# Altium schematic sheet Brightside_Telemetry_power_rev2.SchDoc
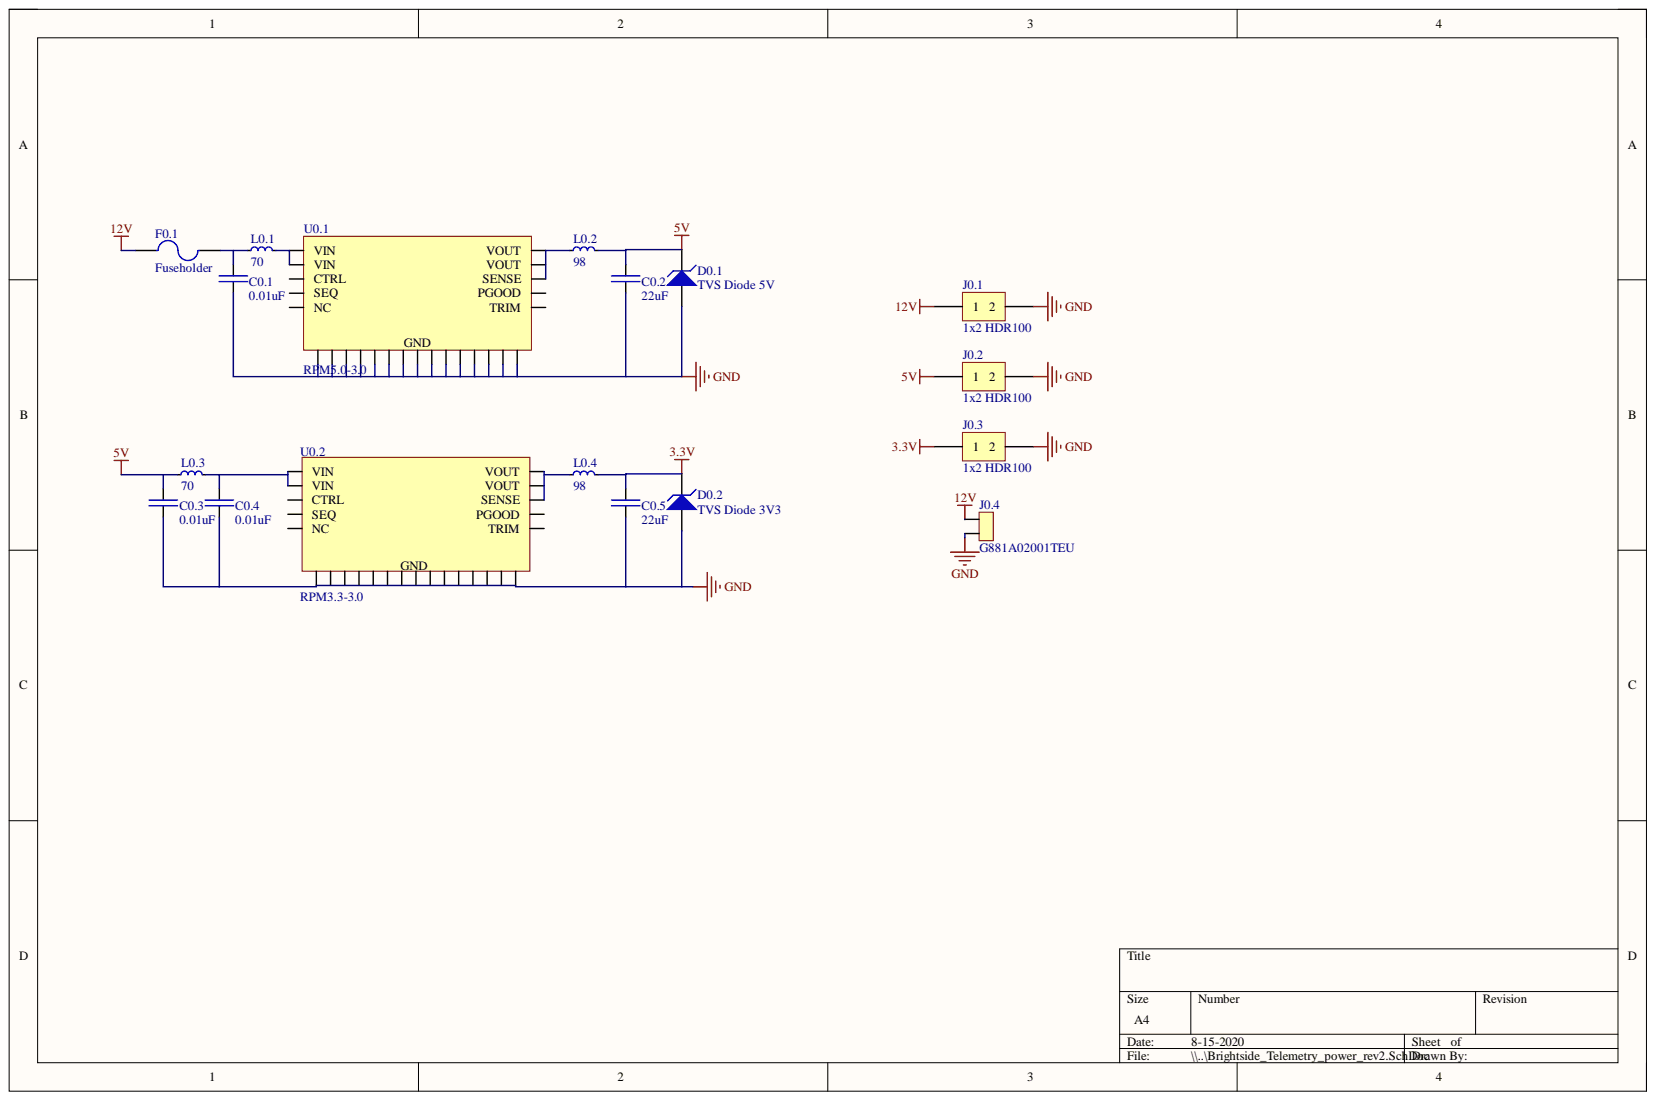
[diagram p0: Brightside_Telemetry_power_rev2.SchDoc]

## Parts
C0.1  0.01uF  [0805]
C0.2  22uF  [1206]
C0.3  0.01uF  [0805]
C0.4  0.01uF  [0805]
C0.5  22uF  [1206]
D0.1  TVS Diode 5V  Operating Supply Voltage: 5V  [SOD-323]
D0.2  TVS Diode 3V3  Operating Supply Voltage: 3.3V  [SOD-323]
F0.1  Fuseholder  [SCHURTER - 0031.7701.11 - FUSE HOLDER, PCB MOUNT]
J0.1  1x2 HDR100  [Molex 0901200762]
J0.2  1x2 HDR100  [Molex 0901200762]
J0.3  1x2 HDR100  [Molex 0901200762]
J0.4  G881A02001TEU  [Micro-Fit 3.0 2pos]
L0.1  70  [1812]
L0.2  98  [7427932]
L0.3  70  [1812]
L0.4  98  [7427932]
U0.1  RPM5.0-3.0  [25-BLGA]
U0.2  RPM3.3-3.0  [25-BLGA]

## Nets
3.3V: C0.5.2 D0.2.2 J0.3.1 L0.4.2
5V: C0.2.2 C0.3.2 D0.1.2 J0.2.1 L0.2.2 L0.3.1
12V: F0.1.1 J0.1.1 J0.4.1
GND: C0.1.1 C0.2.1 C0.3.1 C0.4.1 C0.5.1 D0.1.1 D0.2.1 J0.1.2 J0.2.2 J0.3.2 J0.4.2 U0.1.A3 U0.1.A4 U0.1.B1 U0.1.B2 U0.1.B3 U0.1.B4 U0.1.C2 U0.1.C3 U0.1.C4 U0.1.D2 U0.1.D3 U0.1.D4 U0.1.D5 U0.1.E3 U0.1.E4 U0.2.A3 U0.2.A4 U0.2.B1 U0.2.B2 U0.2.B3 U0.2.B4 U0.2.C2 U0.2.C3 U0.2.C4 U0.2.D2 U0.2.D3 U0.2.D4 U0.2.D5 U0.2.E3 U0.2.E4
NetC0.1_2: C0.1.2 F0.1.2 L0.1.1
NetC0.4_2: C0.4.2 L0.3.2 U0.2.A1(VIN) U0.2.A2(VIN)
NetL0.1_2: L0.1.2 U0.1.A1(VIN) U0.1.A2(VIN)
NetL0.2_1: L0.2.1 U0.1.A5(VOUT) U0.1.B5(VOUT) U0.1.C5(SENSE)
NetL0.4_1: L0.4.1 U0.2.A5(VOUT) U0.2.B5(VOUT) U0.2.C5(SENSE)

Not connected: U0.1 C1(CTRL) D1(PGOOD) E1(SEQ) E2(NC) E5(TRIM); U0.2 C1(CTRL) D1(PGOOD) E1(SEQ) E2(NC) E5(TRIM)

## Library data of the parts
C0.1 C0.3 C0.4 = C0805C103K1RAC7210: Cap Ceramic 0.01uF 100V X7R 10% SMD 0805 125C T/R; Manufacturer: KEMET; Capacitance: 10nF; Case Code (Imperial): 0805; Case Code (Metric): 2012; Case/Package: 0805; Category: Ceramic Capacitors; Component Kind: Standard; ComponentLink1Description: http://www.mouser.com/ds/2/212/KEM_C1002_X7R_SMD-1102033.pdf?src-supplier=Mouser; ComponentLink1URL: http://www.mouser.com/ds/2/212/KEM_C1002_X7R_SMD-1102033.pdf?src-supplier=Mouser; ComponentLink2Description: https://www.verical.com/server-webapp/html/getDatasheet?partID=131027&src-supplier=Verical; ComponentLink2URL: https://www.verical.com/server-webapp/html/getDatasheet?partID=131027&src-supplier=Verical; ComponentLink3Description: http://components.arrow.com/part/dataview/0323_00324583?src-supplier=Arrow+Europe; ComponentLink3URL: http://components.arrow.com/part/dataview/0323_00324583?src-supplier=Arrow+Europe; ComponentLink4Description: http://www.farnell.com/datasheets/2747800.pdf?src-supplier=Farnell; ComponentLink4URL: http://www.farnell.com/datasheets/2747800.pdf?src-supplier=Farnell; ComponentLink5Description: http://components.arrow.com/part/dataview/2091052S6675795N7429?src-supplier=Arrow; ComponentLink5URL: http://components.arrow.com/part/dataview/2091052S6675795N7429?src-supplier=Arrow; ComponentLink6Description: http://www.farnell.com/datasheets/2747800.pdf?src-supplier=Newark; ComponentLink6URL: http://www.farnell.com/datasheets/2747800.pdf?src-supplier=Newark; ComponentLink7Description: http://www.kemet.com/kemet/web/homepage/kechome.nsf/weben/C4DA2DA1D1C9D3C3CA2570A50016092D/$file/KEM_C1002_X7R_SMD.pdf?src-supplier=Avnet+Europe; ComponentLink7URL: http://www.kemet.com/kemet/web/homepage/kechome.nsf/weben/C4DA2DA1D1C9D3C3CA2570A50016092D/$file/KEM_C1002_X7R_SMD.pdf?src-supplier=Avnet+Europe; ComponentLink8Description: http://www.kemet.com/kemet/web/homepage/kechome.nsf/weben/C4DA2DA1D1C9D3C3CA2570A50016092D/$file/KEM_C1002_X7R_SMD.pdf?src-supplier=Avnet; ComponentLink8URL: http://www.kemet.com/kemet/web/homepage/kechome.nsf/weben/C4DA2DA1D1C9D3C3CA2570A50016092D/$file/KEM_C1002_X7R_SMD.pdf?src-supplier=Avnet; ComponentLink9Description: https://api.kemet.com/component-edge/download/datasheet/C0805C103K1RAC7210.pdf?src-supplier=Digi-Key; ComponentLink9URL: https://api.kemet.com/component-edge/download/datasheet/C0805C103K1RAC7210.pdf?src-supplier=Digi-Key; Depth: 1.25mm; Dielectric: X7R; Dielectric Material: Ceramic; Height: 0.78mm; Length: 2mm; Library Name: Capacitors.SchLib; Manufacturer Part Number: C0805C103K1RAC7210; Max Operating Temperature: 125°C; Min Operating Temperature: -55°C; Mount: Surface Mount; Package Quantity: 10000; Packaging: Tape and Reel; Radiation Hardening: No; RoHS: Yes; Stock 1: 43378; Supplier 1: Digi-Key; Supplier Part Number 1: 399-17617-1-ND; Termination: SMD/SMT; Tolerance: 10%; Voltage Rating: 100V; Voltage Rating (DC): 100V
C0.2 C0.5 = CL31B226KPHNNNE: CAP CER 22UF 10V X7R 1206; Manufacturer: Samsung; Tolerance: 10%; Capacitance: 22uF; Case Code (Imperial): 1206; Case Code (Metric): 3216; Case/Package: 1206; Category: Ceramic Capacitors; Component Kind: Standard; ComponentLink1Description: https://datasheet.ciiva.com/25724/cl31b226kphnnne-25724443.pdf?src-supplier=Digi-Key; ComponentLink1URL: https://datasheet.ciiva.com/25724/cl31b226kphnnne-25724443.pdf?src-supplier=Digi-Key; ComponentLink2Description: https://datasheet.ciiva.com/16384/cl31b226kphnnne-16384588.pdf?src-supplier=Future+Electronics; ComponentLink2URL: https://datasheet.ciiva.com/16384/cl31b226kphnnne-16384588.pdf?src-supplier=Future+Electronics; ComponentLink3Description: https://datasheet.ciiva.com/26838/getdatasheetpartid-710483-26838941.pdf?src-supplier=Verical; ComponentLink3URL: https://datasheet.ciiva.com/26838/getdatasheetpartid-710483-26838941.pdf?src-supplier=Verical; Contact Plating: Tin; Depth: 1.6mm; Dielectric: X7R; Lead Free: Lead Free; Length: 3.2mm; Library Name: Capacitors.SchLib; Manufacturer Part Number: CL31B226KPHNNNE; Max Operating Temperature: 125°C; Min Operating Temperature: -55°C; Mount: Surface Mount; Package Quantity: 2000; Packaging: Tape and Reel; Radiation Hardening: No; RoHS: Yes; Stock 1: 617814; Supplier 1: Digi-Key; Supplier Part Number 1: 1276-2769-1-ND; Termination: Wraparound; Thickness: 0.071inch; Voltage Rating: 10V; Voltage Rating (DC): 10V; Width: 0.063inch
D0.1 = SD05T1G: TVS DIODE 5V 9.8V SOD323; Manufacturer: ON Semiconductor; Breakdown Voltage: 6.75V; Capacitance: 350pF; Clamping Voltage: 14.5V; Direction: Unidirectional; Element Configuration: Single; ESD Protection: Yes; Leakage Current: 10uA; Max Breakdown Voltage: 7.3V; Max Output Current: 24A; Max Power Dissipation: 200mW; Min Breakdown Voltage: 6.2V; Number of Elements: 1; Peak Pulse Power: 350W; Power Line Protection: No; Test Current: 1mA; Case/Package: SOD-323-2; Category: Circuit Protection; Component Kind: Standard; ComponentLink1Description: https://datasheet.ciiva.com/4507/sd05t1-d-241634-4507873.pdf?src-supplier=Mouser; ComponentLink1URL: https://datasheet.ciiva.com/4507/sd05t1-d-241634-4507873.pdf?src-supplier=Mouser; ComponentLink2Description: https://datasheet.ciiva.com/16200/sd05t1-d-16200994.pdf?src-supplier=Future+Electronics; ComponentLink2URL: https://datasheet.ciiva.com/16200/sd05t1-d-16200994.pdf?src-supplier=Future+Electronics; ComponentLink3Description: https://datasheet.ciiva.com/15143/getdatasheetpartid-117154-15143559.pdf?src-supplier=Verical; ComponentLink3URL: https://datasheet.ciiva.com/15143/getdatasheetpartid-117154-15143559.pdf?src-supplier=Verical; ComponentLink4Description: https://datasheet.ciiva.com/30478/0900766b81250bd7-30478714.pdf?src-supplier=RSComponents; ComponentLink4URL: https://datasheet.ciiva.com/30478/0900766b81250bd7-30478714.pdf?src-supplier=RSComponents; ComponentLink5Description: https://datasheet.ciiva.com/339/sd05t1-d-339830.pdf?src-supplier=Digi-Key; ComponentLink5URL: https://datasheet.ciiva.com/339/sd05t1-d-339830.pdf?src-supplier=Digi-Key; ComponentLink6Description: https://datasheet.ciiva.com/339/sd05t1-d-339831.pdf?src-supplier=Digi-Key; ComponentLink6URL: https://datasheet.ciiva.com/339/sd05t1-d-339831.pdf?src-supplier=Digi-Key; ComponentLink7Description: https://datasheet.ciiva.com/11193/sd05t1-d-11193467.pdf?src-supplier=Avnet; ComponentLink7URL: https://datasheet.ciiva.com/11193/sd05t1-d-11193467.pdf?src-supplier=Avnet; ComponentLink8Description: https://datasheet.ciiva.com/22637/1878253-22637228.pdf?src-supplier=Element14; ComponentLink8URL: https://datasheet.ciiva.com/22637/1878253-22637228.pdf?src-supplier=Element14; ComponentLink9Description: https://datasheet.ciiva.com/25956/sd05t1-d-25956638.pdf?src-supplier=Avnet+Europe; ComponentLink9URL: https://datasheet.ciiva.com/25956/sd05t1-d-25956638.pdf?src-supplier=Avnet+Europe; Composition: Zener; Contact Plating: Tin; Depth: 1.25mm; Height: 1mm; Lead Free: Lead Free; Length: 1.7mm; Library Name: Diodes.SchLib; Manufacturer Part Number: SD05T1G; Max Operating Temperature: 150°C; Max Reverse Leakage Current: 10uA; Max Surge Current: 24A; Min Operating Temperature: -55°C; Number of Pins: 2; Number of Unidirectional Channels: 1; Package Quantity: 1; Packaging: Tape and Reel; Peak Pulse Current: 24A; Polarity: Unidirectional; Power Dissipation: 350W; Pricing 1: 1 = 0.49000, 10 = 0.36500, 100 = 0.20680, 500 = 0.13698, 1000 = 0.10502 (USD); Radiation Hardening: No; REACH SVHC: No SVHC; Reverse Breakdown Voltage: 6.2V; Reverse Standoff Voltage: 5V; RoHS: Yes; Stock 1: 61559; Supplier 1: Digi-Key; Supplier Part Number 1: SD05T1GOSCT-ND; Termination: SMD/SMT; Voltage Rating (DC): 5V; Width: 1.35mm; Working Voltage: 5V
D0.2 = CDSOD323-T03S: ESD Suppressor TVS ±30kV 2-Pin SOD-323 T/R; Manufacturer: Bourns; Breakdown Voltage: 4V; Capacitance: 500pF; Clamping Voltage: 10.9V; Direction: Unidirectional; Element Configuration: Single; Leakage Current: 125uA; Max Power Dissipation: 500W; Max Surge Current: 43A; Number of Channels: 1; Power Line Protection: No; Case/Package: SOD-323-2; Category: Circuit Protection; Component Kind: Standard; ComponentLink1Description: https://datasheet.ciiva.com/22685/1671000-22685541.pdf?src-supplier=Element14; ComponentLink1URL: https://datasheet.ciiva.com/22685/1671000-22685541.pdf?src-supplier=Element14; ComponentLink2Description: https://datasheet.ciiva.com/6575/cdsod323-txxsc-6575941.pdf?src-supplier=Digi-Key; ComponentLink2URL: https://datasheet.ciiva.com/6575/cdsod323-txxsc-6575941.pdf?src-supplier=Digi-Key; ComponentLink3Description: https://datasheet.ciiva.com/11193/cdsod323-txxsc-11193742.pdf?src-supplier=Avnet; ComponentLink3URL: https://datasheet.ciiva.com/11193/cdsod323-txxsc-11193742.pdf?src-supplier=Avnet; Composition: Zener; Contact Plating: Tin; Depth: 1.45mm; Length: 1.9mm; Library Name: Diodes.SchLib; Manufacturer Part Number: CDSOD323-T03S; Max Operating Temperature: 150°C; Min Breakdown Voltage: 4V; Min Operating Temperature: -55°C; Mount: Surface Mount; Number of Pins: 2; Number of Unidirectional Channels: 1; Package Quantity: 3000; Packaging: Tape and Reel; Peak Pulse Current: 43A; Peak Pulse Power: 500W; Polarity: Unidirectional; Pricing 1: 1 = 0.72000, 10 = 0.60600, 25 = 0.53000, 100 = 0.45420, 250 = 0.39368, 500 = 0.33310, 1000 = 0.25740 (USD); Radiation Hardening: No; REACH SVHC: No SVHC; Reverse Standoff Voltage: 3.3V; RoHS: Yes; Stock 1: 99362; Supplier 1: Digi-Key; Supplier Part Number 1: CDSOD323-T03SCT-ND; Termination: SMD/SMT; Working Voltage: 3.3V
F0.1 = 0031.7701.11: SCHURTER - 0031.7701.11 - FUSE HOLDER, PCB MOUNT; Body Material: Thermoplastic; Color: White; Current Rating: 5A; Illumination Type: Illuminated; Material: Tin; Number of Circuits: 1; Voltage: 125V; Category: Circuit Protection; Component Kind: Standard; ComponentLink1Description: https://datasheet.ciiva.com/1270/typ-omh-125-1270896.pdf?src-supplier=Element14; ComponentLink1URL: https://datasheet.ciiva.com/1270/typ-omh-125-1270896.pdf?src-supplier=Element14; ComponentLink2Description: https://datasheet.ciiva.com/7496/typ-omh-125-7496724.pdf?src-supplier=Digi-Key; ComponentLink2URL: https://datasheet.ciiva.com/7496/typ-omh-125-7496724.pdf?src-supplier=Digi-Key; ComponentLink3Description: https://datasheet.ciiva.com/16215/typ-omh-125-16215096.pdf?src-supplier=Onlinecomponents.com; ComponentLink3URL: https://datasheet.ciiva.com/16215/typ-omh-125-16215096.pdf?src-supplier=Onlinecomponents.com; ComponentLink4Description: https://datasheet.ciiva.com/30058/73typ-omh-125-30058618.pdf?src-supplier=Onlinecomponents.com; ComponentLink4URL: https://datasheet.ciiva.com/30058/73typ-omh-125-30058618.pdf?src-supplier=Onlinecomponents.com; ComponentLink5Description: https://datasheet.ciiva.com/14128/awomh125-data-e-14128364.pdf?src-supplier=Distrelec; ComponentLink5URL: https://datasheet.ciiva.com/14128/awomh125-data-e-14128364.pdf?src-supplier=Distrelec; ComponentLink6Description: https://datasheet.ciiva.com/1221/1925349-1221826.pdf?src-supplier=Newark; ComponentLink6URL: https://datasheet.ciiva.com/1221/1925349-1221826.pdf?src-supplier=Newark; ComponentLink7Description: https://datasheet.ciiva.com/3014/typ-omh-125-3014522.pdf?src-supplier=Avnet; ComponentLink7URL: https://datasheet.ciiva.com/3014/typ-omh-125-3014522.pdf?src-supplier=Avnet; ComponentLink8Description: https://datasheet.ciiva.com/4480/typ-omh-125-4480427.pdf?src-supplier=Mouser; ComponentLink8URL: https://datasheet.ciiva.com/4480/typ-omh-125-4480427.pdf?src-supplier=Mouser; ComponentLink9Description: https://datasheet.ciiva.com/17405/typ-omh-125-17405484.pdf?src-supplier=Avnet+Europe; ComponentLink9URL: https://datasheet.ciiva.com/17405/typ-omh-125-17405484.pdf?src-supplier=Avnet+Europe; ComponentLink10Description: Digikey; ComponentLink10URL: https://www.digikey.ca/product-detail/en/schurter-inc/0031.7701.11/486-1991-ND/640027; Contact Material: Copper Alloy; Contact Plating: Tin; Depth: 5.2mm; Fuse Current: 5A; Height: 6mm; Lead Free: Lead Free; Length: 12mm; Library Name: Fuses & Holders.SchLib; Manufacturer: Schurter; Manufacturer Part Number: 0031.7701.11; Max Operating Temperature: 85°C; Min Operating Temperature: -40°C; Mount: Surface Mount; Number of Poles: 1; Package Quantity: 100; Packaging: Bulk; Pricing 1: 1 = 1.39000, 50 = 1.03400, 100 = 0.93100, 500 = 0.70300, 1000 = 0.52080 (USD); Radiation Hardening: No; REACH SVHC: No SVHC; RoHS: Yes; Stock 1: 11381; Supplier 1: Digi-Key; Supplier Part Number 1: 486-1991-ND; Terminal Material: Brass; Termination: SMD/SMT; Voltage Rating: 125V; Voltage Rating (AC): 125V; Voltage Rating (DC): 125V; Weight: 0.016932oz; Width: 5.2mm
J0.1-J0.3 = 0901200762: CONN HEADER VERT 2POS 2.54MM; Manufacturer: Molex; Connector Type: Male Pin; Contact Resistance: 20mR; Gender: Male; Max Voltage Rating (AC): 350V; Number of Contacts: 2; Category: Connectors; Color: Black; Component Kind: Standard; ComponentLink1Description: https://datasheet.ciiva.com/711/901200762-sd-711939.pdf?src-supplier=Digi-Key; ComponentLink1URL: https://datasheet.ciiva.com/711/901200762-sd-711939.pdf?src-supplier=Digi-Key; ComponentLink2Description: https://datasheet.ciiva.com/3117/0901200762-pcb-headers-3117809.pdf?src-supplier=Avnet; ComponentLink2URL: https://datasheet.ciiva.com/3117/0901200762-pcb-headers-3117809.pdf?src-supplier=Avnet; Contact Material: Brass; Contact Mating Length: 0.266inch; Contact Plating: Gold; Depth: 2.54mm; Housing Color: Black; Housing Material: Polyester; Length: 5.08mm; Library Name: Connectors.SchLib; Manufacturer Part Number: 0901200762; Max Operating Temperature: 125°C; Max Voltage Rating (DC): 350V; Min Operating Temperature: -55°C; Mount: Through Hole; Number of Positions: 2; Number of Rows: 1; Orientation: Straight; Packaging: Bulk; Pitch: 2.54mm; Pricing 1: 1 = 0.560000, 10 = 0.530000, 100 = 0.406000, 500 = 0.353000, 1000 = 0.291230, 5000 = 0.255930, 10000 = 0.238280 (USD); RoHS: Yes; Stock 1: 37733; Supplier 1: Digi-Key; Supplier Part Number 1: WM8084-ND; Termination: Solder
J0.4 = G881A02001TEU: Micro-fit 3.0 2pos right-angle; Manufacturer: Molex; Manufacturer Part Number: 0430450200; Connector Type: Male Pin; Contact Resistance: 10mR; Max Voltage Rating (AC): 250V; Number of Contacts: 2; Pitch: 3mm; Row Spacing: 0.118inch; Category: Headers and Wire Housings; Color: Black; Component Kind: Standard; ComponentLink1Description: https://datasheet.ciiva.com/712/430450200-sd-712017.pdf?src-supplier=Digi-Key; ComponentLink1URL: https://datasheet.ciiva.com/712/430450200-sd-712017.pdf?src-supplier=Digi-Key; ComponentLink2Description: https://datasheet.ciiva.com/3933/159670430450200-pcb-headers-3933176.pdf?src-supplier=Arrow; ComponentLink2URL: https://datasheet.ciiva.com/3933/159670430450200-pcb-headers-3933176.pdf?src-supplier=Arrow; Contact Material: Brass; Contact Plating: Tin; Depth: 12.2mm; Fastening Type: Ramp; Flammability Rating: UL94 V-0; Housing Color: Black; Housing Material: Polymer; Length: 7.15mm; Library Name: Connectors.SchLib; Max Operating Temperature: 105°C; Min Operating Temperature: -40°C; Mount: Through Hole; Number of Positions: 2; Number of Rows: 2; Orientation: Right Angle; Packaging: Tray; RoHS: Yes; Stock 1: 9248; Supplier 1: Digi-Key; Supplier Part Number 1: WM1813-ND; Termination: Solder
L0.1 L0.3 = 742792510: WURTH ELEKTRONIK - 742792510 - FERRITE BEAD, 70R, 6A, 1812; Manufacturer: Wurth Electronics; DC Resistance (DCR): 30mR; Max DC Current: 3mA; Number of Lines: 1; Test Frequency: 100MHz; Tolerance: 25%; Case Code (Imperial): 1812; Case Code (Metric): 4532; Case/Package: SMD/SMT; Category: Ferrite Beads and Chips; Component Kind: Standard; ComponentLink1Description: https://datasheet.ciiva.com/1475/1695992-1475250.pdf?src-supplier=Farnell; ComponentLink1URL: https://datasheet.ciiva.com/1475/1695992-1475250.pdf?src-supplier=Farnell; ComponentLink2Description: https://datasheet.ciiva.com/11803/0900766b80bbd134-11803157.pdf?src-supplier=RSComponents; ComponentLink2URL: https://datasheet.ciiva.com/11803/0900766b80bbd134-11803157.pdf?src-supplier=RSComponents; ComponentLink3Description: https://datasheet.ciiva.com/23762/742792510-23762981.pdf?src-supplier=Newark; ComponentLink3URL: https://datasheet.ciiva.com/23762/742792510-23762981.pdf?src-supplier=Newark; ComponentLink4Description: https://datasheet.ciiva.com/4940/742792510-72179-4940456.pdf?src-supplier=Mouser; ComponentLink4URL: https://datasheet.ciiva.com/4940/742792510-72179-4940456.pdf?src-supplier=Mouser; ComponentLink5Description: https://datasheet.ciiva.com/1013/742792510-1013644.pdf?src-supplier=Digi-Key; ComponentLink5URL: https://datasheet.ciiva.com/1013/742792510-1013644.pdf?src-supplier=Digi-Key; ComponentLink6Description: https://datasheet.ciiva.com/1013/742792510-1013645.pdf?src-supplier=Digi-Key; ComponentLink6URL: https://datasheet.ciiva.com/1013/742792510-1013645.pdf?src-supplier=Digi-Key; ComponentLink7Description: https://datasheet.ciiva.com/1126/1695992-1126824.pdf?src-supplier=Element14; ComponentLink7URL: https://datasheet.ciiva.com/1126/1695992-1126824.pdf?src-supplier=Element14; ComponentLink8Description: https://datasheet.ciiva.com/1013/742792510-1013646.pdf?src-supplier=Digi-Key; ComponentLink8URL: https://datasheet.ciiva.com/1013/742792510-1013646.pdf?src-supplier=Digi-Key; Current Rating: 6A; Depth: 3.2mm; Height: 1.5mm; Impedance: 70R; Lead Free: Lead Free; Length: 4.5mm; Library Name: Inductors.SchLib; Manufacturer Part Number: 742792510; Material: Ferrite; Max Current Rating: 6A; Max Operating Temperature: 125°C; Min Operating Temperature: -55°C; Mount: Surface Mount; Package Quantity: 1; Packaging: Tape and Reel; REACH SVHC: Unknown; Resistance: 30mR; RoHS: Yes; Stock 1: 5974; Supplier 1: Digi-Key; Supplier Part Number 1: 732-1640-1-ND; Termination: SMD/SMT; Weight: 0.003951oz; Width: 3.2mm
L0.2 L0.4 = 7427932: Ferrite Bead 98 Ohm 2SMD 1LN; Manufacturer: Wurth Electronics; DC Resistance (DCR): 0.9mR; Impedance: 98R; Max Current Rating: 6A; Number of Lines: 1; Shielding: Unshielded; Test Frequency: 100MHz; Tolerance: 25%; Case Code (Imperial): 1812; Case Code (Metric): 4532; Case/Package: SMD/SMT; Category: Ferrite Beads and Chips; Component Kind: Standard; ComponentLink1Description: https://datasheet.ciiva.com/1014/7427932-1014097.pdf?src-supplier=Digi-Key; ComponentLink1URL: https://datasheet.ciiva.com/1014/7427932-1014097.pdf?src-supplier=Digi-Key; ComponentLink2Description: https://datasheet.ciiva.com/1466/1696119-1466626.pdf?src-supplier=Element14; ComponentLink2URL: https://datasheet.ciiva.com/1466/1696119-1466626.pdf?src-supplier=Element14; ComponentLink3Description: https://datasheet.ciiva.com/11796/0900766b80f886b0-11796146.pdf?src-supplier=RSComponents; ComponentLink3URL: https://datasheet.ciiva.com/11796/0900766b80f886b0-11796146.pdf?src-supplier=RSComponents; ComponentLink4Description: https://datasheet.ciiva.com/23763/7427932-23763047.pdf?src-supplier=Newark; ComponentLink4URL: https://datasheet.ciiva.com/23763/7427932-23763047.pdf?src-supplier=Newark; ComponentLink5Description: https://datasheet.ciiva.com/4939/7427932-72413-4939403.pdf?src-supplier=Mouser; ComponentLink5URL: https://datasheet.ciiva.com/4939/7427932-72413-4939403.pdf?src-supplier=Mouser; ComponentLink6Description: https://datasheet.ciiva.com/1014/7427932-1014098.pdf?src-supplier=Digi-Key; ComponentLink6URL: https://datasheet.ciiva.com/1014/7427932-1014098.pdf?src-supplier=Digi-Key; ComponentLink7Description: https://datasheet.ciiva.com/1014/7427932-1014099.pdf?src-supplier=Digi-Key; ComponentLink7URL: https://datasheet.ciiva.com/1014/7427932-1014099.pdf?src-supplier=Digi-Key; ComponentLink8Description: https://datasheet.ciiva.com/22711/1696119-22711445.pdf?src-supplier=Element14; ComponentLink8URL: https://datasheet.ciiva.com/22711/1696119-22711445.pdf?src-supplier=Element14; Depth: 4.75mm; Height: 3mm; Length: 7.8mm; Library Name: Inductors.SchLib; Manufacturer Part Number: 7427932; Max DC Current: 6A; Max Operating Temperature: 125°C; Min Operating Temperature: -55°C; Mount: Surface Mount; Package Quantity: 1; Packaging: Tape and Reel; REACH SVHC: Unknown; RoHS: Yes; Stock 1: 698; Supplier 1: Digi-Key; Supplier Part Number 1: 732-2394-1-ND; Termination: SMD/SMT; Width: 0.187inch
U0.1 = RPM5.0-3.0: DC-DC Converter; SMD; reg; Single Output; 3A; 5V Out; RPM-3.0 Series; Manufacturer: Recom; Category: Voltage Regulators - Switching; Component Kind: Standard; ComponentLink1Description: http://www.farnell.com/datasheets/2787803.pdf?src-supplier=Farnell; ComponentLink1URL: http://www.farnell.com/datasheets/2787803.pdf?src-supplier=Farnell; ComponentLink2Description: https://www.recom-power.com/pdf/Innoline/RPM-3.0.pdf?src-supplier=Digi-Key; ComponentLink2URL: https://www.recom-power.com/pdf/Innoline/RPM-3.0.pdf?src-supplier=Digi-Key; Library Name: schem_lib.SchLib; Manufacturer Part Number: RPM5.0-3.0; Pricing 1: 1=4.26000 (USD); RoHS: No; Stock 1: 929; Supplier 1: Digi-Key; Supplier Part Number 1: 945-3254-1-ND
U0.2 = RPM3.3-3.0: RPM-3.0 Series 3 to 17 V Input 3.3 V Output 3 A Non Isolated DC/DC Converter; Manufacturer: Recom; Category: Voltage Regulators - Switching; Component Kind: Standard; ComponentLink1Description: https://www.recom-power.com/pdf/Innoline/RPM-3.0.pdf?src-supplier=Digi-Key; ComponentLink1URL: https://www.recom-power.com/pdf/Innoline/RPM-3.0.pdf?src-supplier=Digi-Key; Library Name: schem_lib.SchLib; Manufacturer Part Number: RPM3.3-3.0; Pricing 1: 1=4.26000 (USD); RoHS: No; Stock 1: 287; Supplier 1: Digi-Key; Supplier Part Number 1: 945-3253-1-ND
